# Altium schematic sheet Encoder.SchDoc(Encoder)
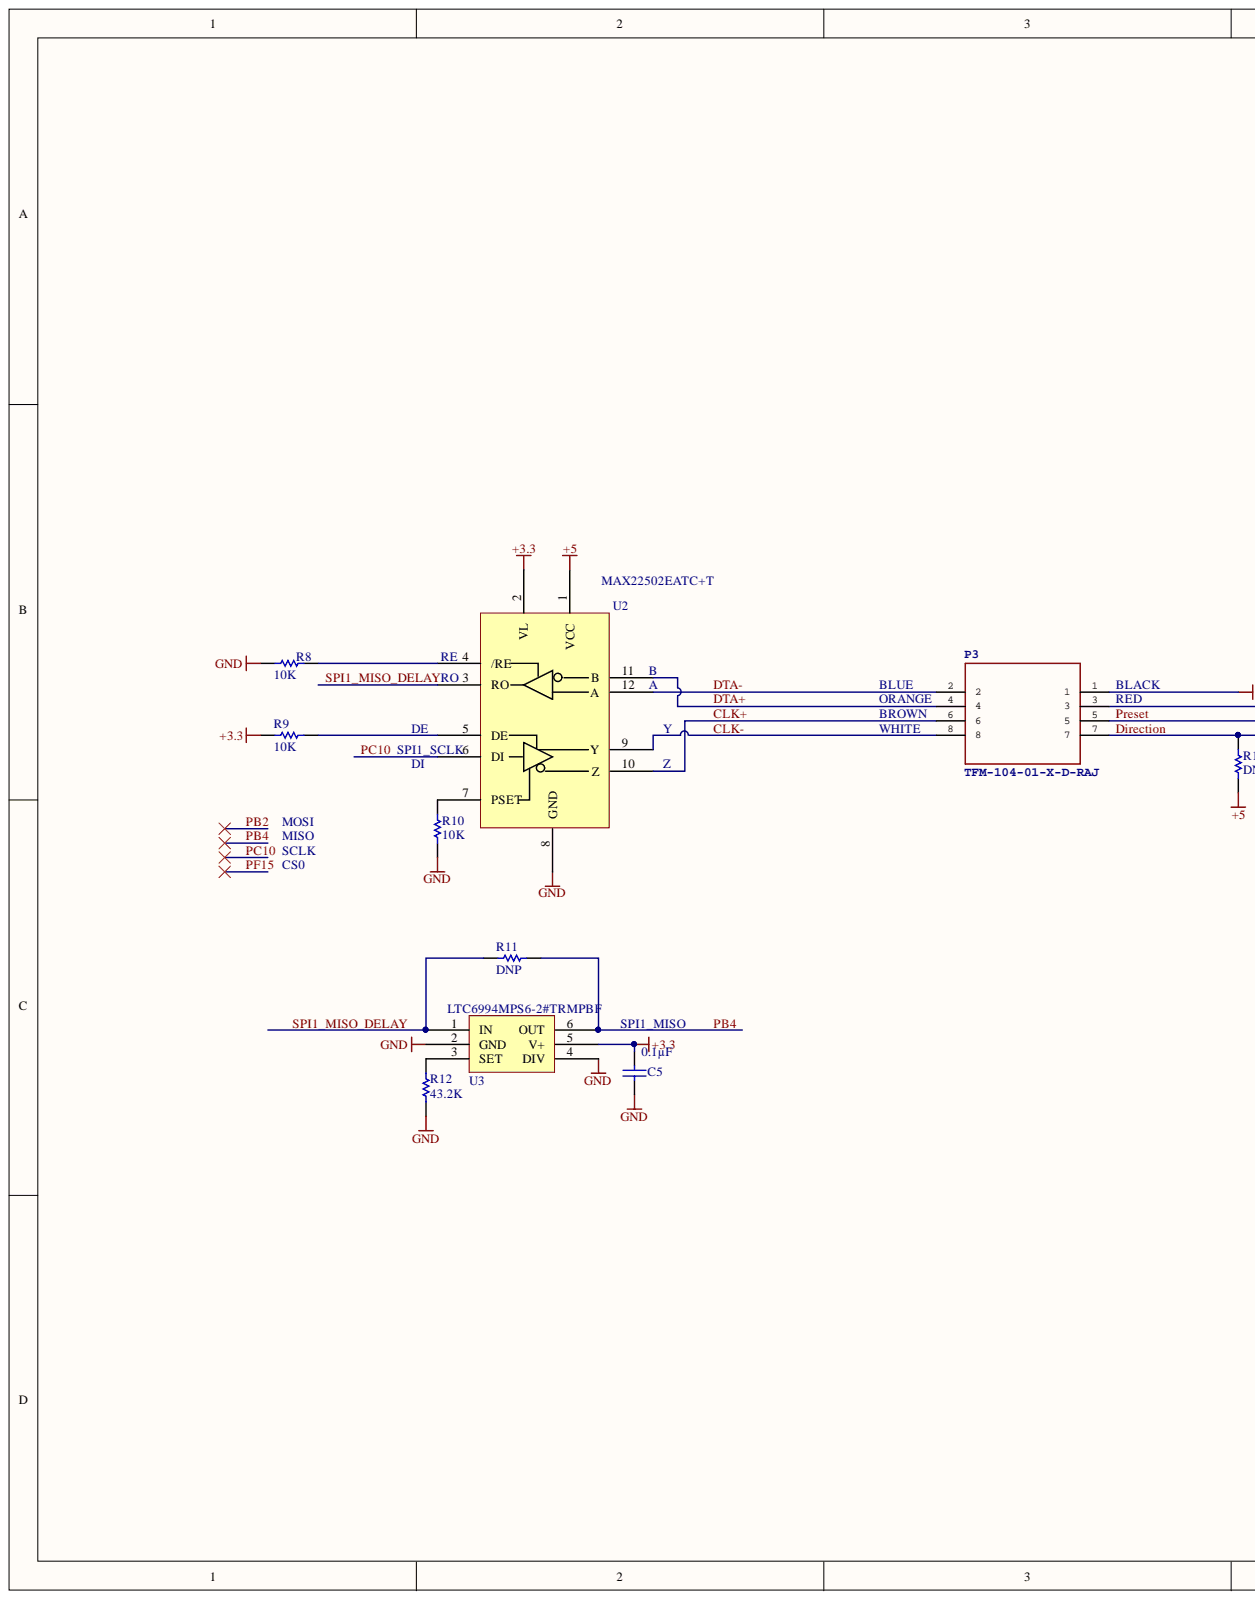
[diagram p0: Encoder.SchDoc, left]
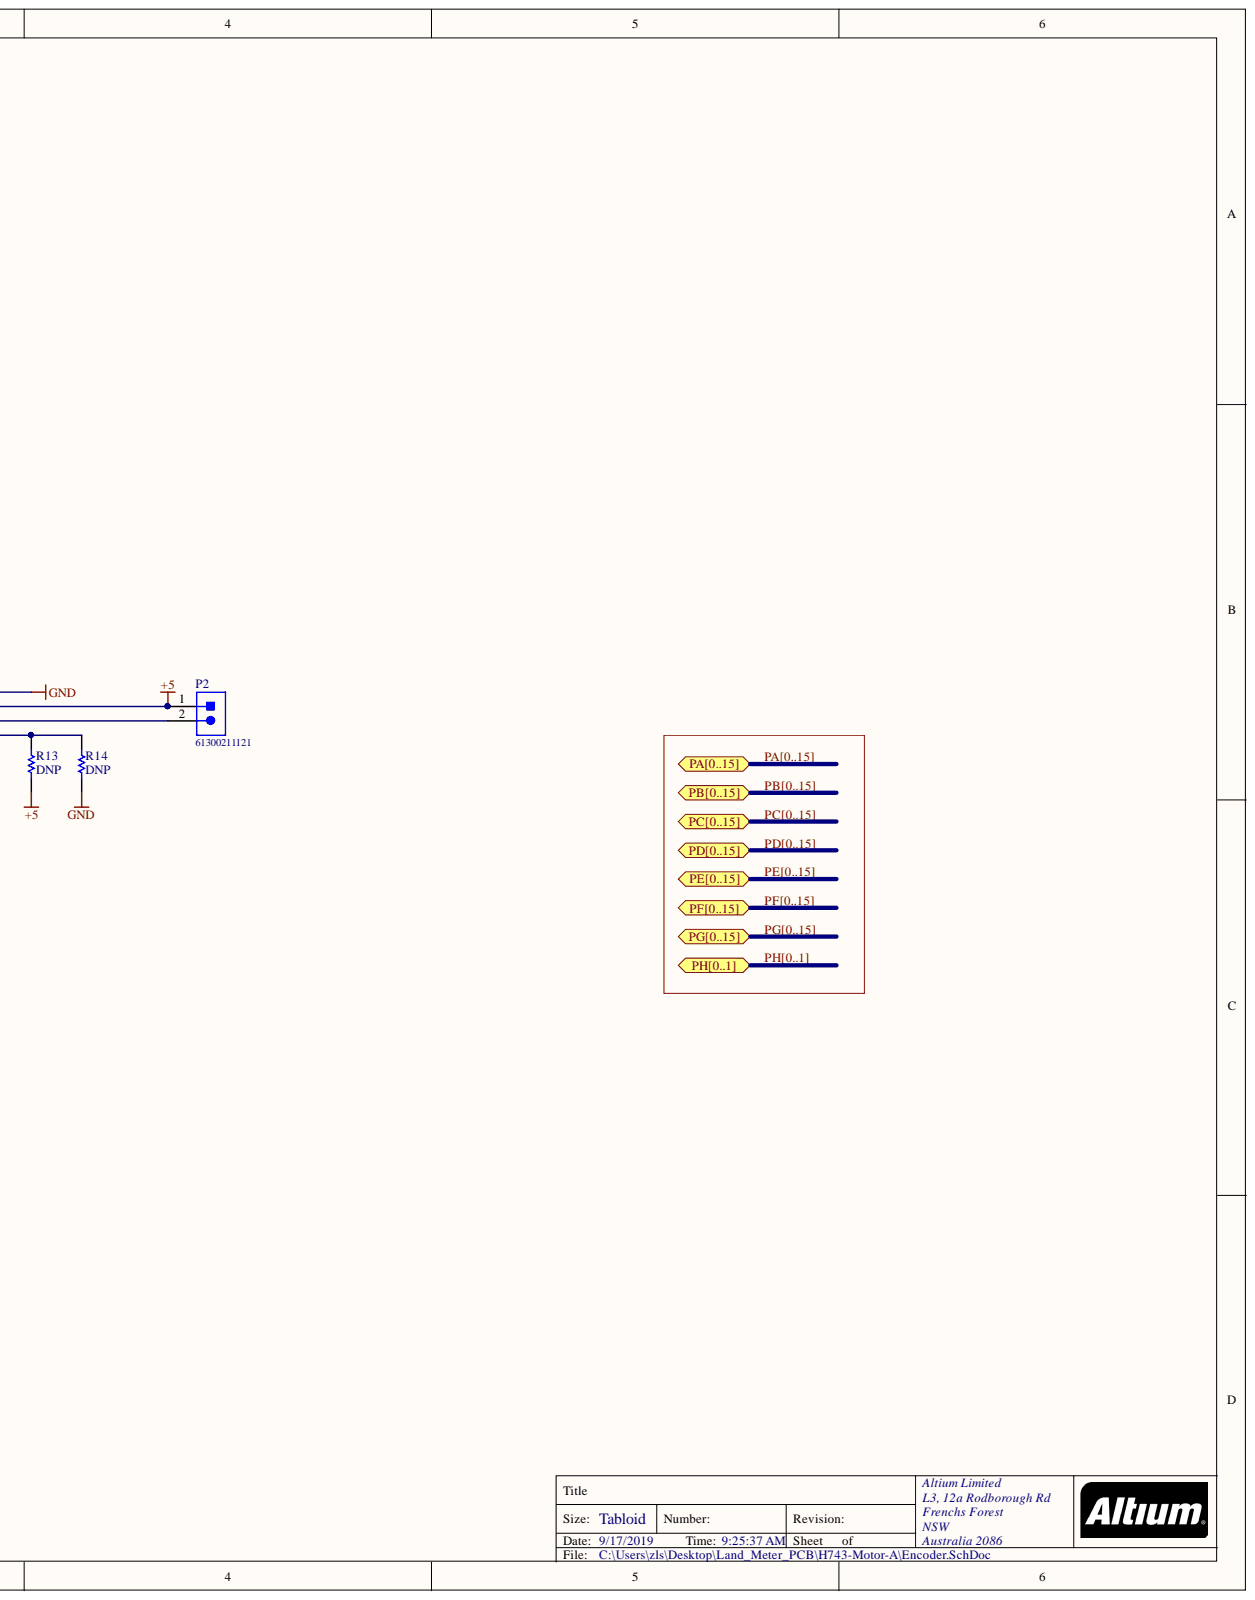
[diagram p1: Encoder.SchDoc, right]

## Parts
C5  Capacitance: 0.1µF  [CAPC0805(2012)145_N]  (hidden comment: CAP CER 0.1UF 25V X7R 0805)
P2  61300211121  [61300211121]
P3  TFM-104-01-X-D-RAJ  [SAMTEC-TFM-104-01-X-D-RA]
R8  10K  [RESC0805(2012)_L]
R9  10K  [RESC0805(2012)_L]
R10  10K  [RESC0805(2012)_L]
R11  DNP  [RESC0805(2012)_L]
R12  43.2K  [RESC0805(2012)_L]
R13  DNP  [RESC0805(2012)_L]
R14  DNP  [RESC0805(2012)_L]
U2  MAX22502EATC+T  [MAX22502EATC+T]
U3  LTC6994MPS6-2#TRMPBF  [LTC6994MPS6-2#TRMPBF]

## Ports
PA0, PA1, PA2, PA3, PA4, PA5, PA6, PA7, PA8, PA9, PA10, PA11, PA12, PA13, PA14, PA15, PA[0..15], PB0, PB1, PB2, PB3, PB4, PB5, PB6, PB7, PB8, PB9, PB10, PB11, PB12, PB13, PB14, PB15, PB[0..15], PC0, PC1, PC2, PC3, PC4, PC5, PC6, PC7, PC8, PC9, PC10, PC11, PC12, PC13, PC14, PC15, PC[0..15], PD0, PD1, PD2, PD3, PD4, PD5, PD6, PD7, PD8, PD9, PD10, PD11, PD12, PD13, PD14, PD15, PD[0..15], PE0, PE1, PE2, PE3, PE4, PE5, PE6, PE7, PE8, PE9, PE10, PE11, PE12, PE13, PE14, PE15, PE[0..15], PF0, PF1, PF2, PF3, PF4, PF5, PF6, PF7, PF8, PF9, PF10, PF11, PF12, PF13, PF14, PF15, PF[0..15], PG0, PG1, PG2, PG3, PG4, PG5, PG6, PG7, PG8, PG9, PG10, PG11, PG12, PG13, PG14, PG15, PG[0..15], PH0, PH1, PH[0..1]

## Nets
+3.3: C5.1 R9.2 U2.2(VL) U3.5(V+)
+5: P2.1 P3.3 R13.1 U2.1(VCC)
GND: C5.2 P3.1 R8.2 R10.2 R12.2 R14.1 U2.8(GND) U3.2(GND) U3.4(DIV)
CLK+: P3.6 U2.10(Z)
CLK-: P3.8 U2.9(Y)
Direction: P3.7 R13.2 R14.2
DTA+: P3.4 U2.11(B)
DTA-: P3.2 U2.12(A)
PB4: R11.2 U3.6(OUT) ; port PB[0..15]  [also labelled PB]
PC10: U2.6(DI) ; port PC[0..15]  [also labelled PC]
Preset: P2.2 P3.5
SPI1_MISO_DELAY: R11.1 U2.3(RO) U3.1(IN)
NetR8_1: R8.1 U2.4(/RE)
NetR9_1: R9.1 U2.5(DE)
NetR10_1: R10.1 U2.7(PSET)
NetR12_1: R12.1 U3.3(SET)
PA0: ; port PA[0..15]  [also labelled PA]
PA1: ; port PA[0..15]  [also labelled PA]
PA2: ; port PA[0..15]  [also labelled PA]
PA3: ; port PA[0..15]  [also labelled PA]
PA4: ; port PA[0..15]  [also labelled PA]
PA5: ; port PA[0..15]  [also labelled PA]
PA6: ; port PA[0..15]  [also labelled PA]
PA7: ; port PA[0..15]  [also labelled PA]
PA8: ; port PA[0..15]  [also labelled PA]
PA9: ; port PA[0..15]  [also labelled PA]
PA10: ; port PA[0..15]  [also labelled PA]
PA11: ; port PA[0..15]  [also labelled PA]
PA12: ; port PA[0..15]  [also labelled PA]
PA13: ; port PA[0..15]  [also labelled PA]
PA14: ; port PA[0..15]  [also labelled PA]
PA15: ; port PA[0..15]  [also labelled PA]
PB0: ; port PB[0..15]  [also labelled PB]
PB1: ; port PB[0..15]  [also labelled PB]
PB2: ; port PB[0..15]  [also labelled PB]
PB3: ; port PB[0..15]  [also labelled PB]
PB5: ; port PB[0..15]  [also labelled PB]
PB6: ; port PB[0..15]  [also labelled PB]
PB7: ; port PB[0..15]  [also labelled PB]
PB8: ; port PB[0..15]  [also labelled PB]
PB9: ; port PB[0..15]  [also labelled PB]
PB10: ; port PB[0..15]  [also labelled PB]
PB11: ; port PB[0..15]  [also labelled PB]
PB12: ; port PB[0..15]  [also labelled PB]
PB13: ; port PB[0..15]  [also labelled PB]
PB14: ; port PB[0..15]  [also labelled PB]
PB15: ; port PB[0..15]  [also labelled PB]
PC0: ; port PC[0..15]  [also labelled PC]
PC1: ; port PC[0..15]  [also labelled PC]
PC2: ; port PC[0..15]  [also labelled PC]
PC3: ; port PC[0..15]  [also labelled PC]
PC4: ; port PC[0..15]  [also labelled PC]
PC5: ; port PC[0..15]  [also labelled PC]
PC6: ; port PC[0..15]  [also labelled PC]
PC7: ; port PC[0..15]  [also labelled PC]
PC8: ; port PC[0..15]  [also labelled PC]
PC9: ; port PC[0..15]  [also labelled PC]
PC11: ; port PC[0..15]  [also labelled PC]
PC12: ; port PC[0..15]  [also labelled PC]
PC13: ; port PC[0..15]  [also labelled PC]
PC14: ; port PC[0..15]  [also labelled PC]
PC15: ; port PC[0..15]  [also labelled PC]
PD0: ; port PD[0..15]  [also labelled PD]
PD1: ; port PD[0..15]  [also labelled PD]
PD2: ; port PD[0..15]  [also labelled PD]
PD3: ; port PD[0..15]  [also labelled PD]
PD4: ; port PD[0..15]  [also labelled PD]
PD5: ; port PD[0..15]  [also labelled PD]
PD6: ; port PD[0..15]  [also labelled PD]
PD7: ; port PD[0..15]  [also labelled PD]
PD8: ; port PD[0..15]  [also labelled PD]
PD9: ; port PD[0..15]  [also labelled PD]
PD10: ; port PD[0..15]  [also labelled PD]
PD11: ; port PD[0..15]  [also labelled PD]
PD12: ; port PD[0..15]  [also labelled PD]
PD13: ; port PD[0..15]  [also labelled PD]
PD14: ; port PD[0..15]  [also labelled PD]
PD15: ; port PD[0..15]  [also labelled PD]
PE0: ; port PE[0..15]  [also labelled PE]
PE1: ; port PE[0..15]  [also labelled PE]
PE2: ; port PE[0..15]  [also labelled PE]
PE3: ; port PE[0..15]  [also labelled PE]
PE4: ; port PE[0..15]  [also labelled PE]
PE5: ; port PE[0..15]  [also labelled PE]
PE6: ; port PE[0..15]  [also labelled PE]
PE7: ; port PE[0..15]  [also labelled PE]
PE8: ; port PE[0..15]  [also labelled PE]
PE9: ; port PE[0..15]  [also labelled PE]
PE10: ; port PE[0..15]  [also labelled PE]
PE11: ; port PE[0..15]  [also labelled PE]
PE12: ; port PE[0..15]  [also labelled PE]
PE13: ; port PE[0..15]  [also labelled PE]
PE14: ; port PE[0..15]  [also labelled PE]
PE15: ; port PE[0..15]  [also labelled PE]
PF0: ; port PF[0..15]  [also labelled PF]
PF1: ; port PF[0..15]  [also labelled PF]
PF2: ; port PF[0..15]  [also labelled PF]
PF3: ; port PF[0..15]  [also labelled PF]
PF4: ; port PF[0..15]  [also labelled PF]
PF5: ; port PF[0..15]  [also labelled PF]
PF6: ; port PF[0..15]  [also labelled PF]
PF7: ; port PF[0..15]  [also labelled PF]
PF8: ; port PF[0..15]  [also labelled PF]
PF9: ; port PF[0..15]  [also labelled PF]
PF10: ; port PF[0..15]  [also labelled PF]
PF11: ; port PF[0..15]  [also labelled PF]
PF12: ; port PF[0..15]  [also labelled PF]
PF13: ; port PF[0..15]  [also labelled PF]
PF14: ; port PF[0..15]  [also labelled PF]
PF15: ; port PF[0..15]  [also labelled PF]
PG0: ; port PG[0..15]  [also labelled PG]
PG1: ; port PG[0..15]  [also labelled PG]
PG2: ; port PG[0..15]  [also labelled PG]
PG3: ; port PG[0..15]  [also labelled PG]
PG4: ; port PG[0..15]  [also labelled PG]
PG5: ; port PG[0..15]  [also labelled PG]
PG6: ; port PG[0..15]  [also labelled PG]
PG7: ; port PG[0..15]  [also labelled PG]
PG8: ; port PG[0..15]  [also labelled PG]
PG9: ; port PG[0..15]  [also labelled PG]
PG10: ; port PG[0..15]  [also labelled PG]
PG11: ; port PG[0..15]  [also labelled PG]
PG12: ; port PG[0..15]  [also labelled PG]
PG13: ; port PG[0..15]  [also labelled PG]
PG14: ; port PG[0..15]  [also labelled PG]
PG15: ; port PG[0..15]  [also labelled PG]
PH0: ; port PH[0..1]  [also labelled PH]
PH1: ; port PH[0..1]  [also labelled PH]

## Library data of the parts
C5 = CMP-1036-04795-1: Manufacturer Part Number: GRM21BR71E104KA01L; Manufacturer: Murata Electronics North America; Tolerance: ±10%; Voltage - Rated: 25V; Thickness (Max): 0.053" (1.35mm); Temperature Coefficient: X7R; Supplier Part Number 1: 490-1673-6-ND; Supplier 1: Digi-Key; Stock 1: 891652; Size / Dimension: 0.079" L x 0.049" W (2.00mm x 1.25mm); RoHS: RoHS Compliant; Ratings: -; Pricing 1: 1=0.2, 10=0.145, 100=0.0683, 500=0.04788, 1000=0.03964 (USD); Part Status: Active; Packaging: Digi-Reel®; Package / Case: 0805 (2012 Metric); Operating Temperature: -55°C ~ 125°C; Mounting Type: Surface Mount, MLCC; Library Name: Altium Content Vault; Lead Style: -; Lead Spacing: -; Height - Seated (Max): -; Features: -; Description: CAP CER 0.1UF 25V X7R 0805; ComponentLink1URL: http://www.murata.com/~/media/webrenewal/support/library/catalog/products/capacitor/mlcc/c02e.ashx; ComponentLink1Description: http://www.murata.com/~/media/webrenewal/support/library/catalog/products/capacitor/mlcc/c02e.ashx; Component Kind: Standard; Category: Capacitors; Applications: General Purpose
P2 = CMP-1502-01060-1: THT Vertical Pin Header WR-PHD, Pitch 2.54 mm, Single Row, 2 pins; Manufacturer: Wurth Elektronik; Working Voltage: 250VAC; Withstanding Voltage: 500VAC; Voltage Rating: 250V; Rated Current: 3A; Pitch: 2.54mm; Pin Number: 2; Gender: Male; Contact Resistance: 20mOhm; Connector Type: Male Pin; Termination: Through Hole; Supplier Part Number 1: 732-5315-ND; Supplier 1: Digi-Key; Stock 1: 179707; Row Number: Single; RoHS: Yes; REACH SVHC: Unknown; Pricing 1: 1=0.13000, 10=0.10600, 25=0.08800, 50=0.07400, 100=0.06700, 1000=0.06100 (USD); Part Number: 61300211121; Packaging: Bag; Package Reference: 61300211121; Orientation: Vertical; Operating Temperature: -40to105degC; Number of Rows: 1; Number of Positions: 2; Number of Contacts: 2; Mounting Technology: THT; Mount: Through Hole; Min Operating Temperature: -40°C; Max Operating Temperature: 125°C; Manufacturer URL: http://www.we-online.de; Manufacturer Part Number: 61300211121; Library Name: Altium Content Vault; Lead Free: Lead Free; Housing Material: PBT; Datasheet URL: https://katalog.we-online.de/em/datasheet/6130xx11121.pdf; Current Rating: 3A; Contact Plating: Gold; Contact Mating Length: 0.236inch; Contact Material: Copper Alloy,Copper; Contact Gender: Male; ComponentLink1URL: https://datasheet.ciiva.com/13619/6130xx11121-48870-13619345.pdf?src-supplier=Mouser; ComponentLink1Description: https://datasheet.ciiva.com/13619/6130xx11121-48870-13619345.pdf?src-supplier=Mouser; Component Kind: Standard; Color: Black; Publisher: WurthElektronik
P3 = SAMTEC-TFM-104-01-X-D-RAJ: Manufacturer: SAMTEC; Manufacturer Part Number: TFM-104-01-X-D-RAJ; Library Name: SAMTEC-TFM-104-01-X-D-RA.IntLib; Component Kind: Standard
R8-R10 = CMP-1013-00215-1: RES SMD 10K OHM 1% 1/8W 0805; Manufacturer Part Number: CRCW080510K0FKEA; Manufacturer: Vishay Dale; Temperature Coefficient: ±100ppm/°C; Power (Watts): 0.125W, 1/8W; Tolerance: ±1%; Supplier Part Number 1: 541-10.0KCCT-ND; Supplier Device Package: 0805; Supplier 1: Digi-Key; Stock 1: 1104982; Size / Dimension: 0.079" L x 0.049" W (2.00mm x 1.25mm); RoHS: RoHS Compliant; Pricing 1: 1=0.1, 10=0.055, 100=0.0223, 1000=0.01003, 2500=0.0087 (USD); Part Status: Active; Packaging: Cut Tape (CT); Package / Case: 0805 (2012 Metric); Operating Temperature: -55°C ~ 155°C; Library Name: Altium Content Vault; Height - Seated (Max): 0.020" (0.50mm); Features: Automotive AEC-Q200; Failure Rate: -; Composition: Thick Film; ComponentLink1URL: http://www.vishay.com/docs/20035/dcrcwe3.pdf; ComponentLink1Description: http://www.vishay.com/docs/20035/dcrcwe3.pdf; Component Kind: Standard; Category: Resistors
R11 = CMP-1013-00215-1: RES SMD 0 OHM 5% 1/8W 0805; Manufacturer Part Number: CRCW08050000Z0EA; Manufacturer: Vishay; Voltage Rating (DC): 150V; Tolerance: 1%; Temperature Coefficient: 100ppm/°C; Resistance: 0mR; Power Rating: 125mW; Width: 1.25mm; Weight: 0.000194oz; Voltage Rating: 150V; Termination: SMD/SMT; Supplier Part Number 1: 541-0.0ACT-ND; Supplier 1: Digi-Key; Stock 1: 470131; RoHS: Yes; REACH SVHC: Unknown; Radiation Hardening: No; Pricing 1: 1=0.10000, 10=0.04400, 25=0.03160, 50=0.02420, 100=0.01780, 250=0.01360, 500=0.01090, 1000=0.00802 (USD); Packaging: Tape and Reel; Package Quantity: 1; Number of Terminations: 2; Min Operating Temperature: -55°C; Military Standard: Not; Max Power Dissipation: 125mW; Max Operating Temperature: 155°C; Library Name: Altium Content Vault; Length: 2mm; Lead Free: Lead Free; Height: 0.45mm; Features: Automotive AEC-Q200; Depth: 1.25mm; Contact Plating: Tin,Lead; Composition: Thick Film; ComponentLink11URL: https://datasheet.ciiva.com/5543/dcrcwe3-109170-5543096.pdf?src-supplier=Mouser; ComponentLink11Description: https://datasheet.ciiva.com/5543/dcrcwe3-109170-5543096.pdf?src-supplier=Mouser; ComponentLink10URL: https://datasheet.ciiva.com/7841/1720486-7841839.pdf?src-supplier=Element14; ComponentLink10Description: https://datasheet.ciiva.com/7841/1720486-7841839.pdf?src-supplier=Element14; ComponentLink9URL: https://datasheet.ciiva.com/2463/dcrcwe3-2463039.pdf?src-supplier=Digi-Key; ComponentLink9Description: https://datasheet.ciiva.com/2463/dcrcwe3-2463039.pdf?src-supplier=Digi-Key; ComponentLink8URL: https://datasheet.ciiva.com/2463/dcrcwe3-2463038.pdf?src-supplier=Digi-Key; ComponentLink8Description: https://datasheet.ciiva.com/2463/dcrcwe3-2463038.pdf?src-supplier=Digi-Key; ComponentLink7URL: https://datasheet.ciiva.com/7841/1697337-7841629.pdf?src-supplier=Element14; ComponentLink7Description: https://datasheet.ciiva.com/7841/1697337-7841629.pdf?src-supplier=Element14; ComponentLink6URL: https://datasheet.ciiva.com/2463/dcrcwe3-2463037.pdf?src-supplier=Digi-Key; ComponentLink6Description: https://datasheet.ciiva.com/2463/dcrcwe3-2463037.pdf?src-supplier=Digi-Key; ComponentLink5URL: https://datasheet.ciiva.com/16719/70201119-16719706.pdf?src-supplier=Allied+Electronics; ComponentLink5Description: https://datasheet.ciiva.com/16719/70201119-16719706.pdf?src-supplier=Allied+Electronics; ComponentLink4URL: https://datasheet.ciiva.com/16389/dcrcwe3-16389338.pdf?src-supplier=Future+Electronics; ComponentLink4Description: https://datasheet.ciiva.com/16389/dcrcwe3-16389338.pdf?src-supplier=Future+Electronics; ComponentLink3URL: https://datasheet.ciiva.com/26314/fld-crcw-e3-data-en-26314630.pdf?src-supplier=Distrelec; ComponentLink3Description: https://datasheet.ciiva.com/26314/fld-crcw-e3-data-en-26314630.pdf?src-supplier=Distrelec; ComponentLink2URL: https://datasheet.ciiva.com/16883/dcrcwe3-16883622.pdf?src-supplier=Avnet+Europe; ComponentLink2Description: https://datasheet.ciiva.com/16883/dcrcwe3-16883622.pdf?src-supplier=Avnet+Europe; ComponentLink1URL: https://datasheet.ciiva.com/11811/0900766b80d98b26-11811304.pdf?src-supplier=RSComponents; ComponentLink1Description: https://datasheet.ciiva.com/11811/0900766b80d98b26-11811304.pdf?src-supplier=RSComponents; Component Kind: Standard; Case Code (Metric): 2012; Case Code (Imperial): 0805; Case/Package: 0805
R12 = CMP-1013-00215-1: RES SMD 43.2K OHM 1% 1/8W 0805; Manufacturer Part Number: CRCW080543K2FKEA; Manufacturer: Vishay Dale; Temperature Coefficient: ±100ppm/°C; Power (Watts): 0.125W, 1/8W; Tolerance: ±1%; Supplier Part Number 1: 541-43.2KCCT-ND; Supplier Device Package: 0805; Supplier 1: Digi-Key; Stock 1: 68577; Size / Dimension: 0.079" L x 0.049" W (2.00mm x 1.25mm); RoHS: RoHS Compliant; Pricing 1: 1=0.1, 10=0.055, 100=0.0223, 1000=0.01003, 2500=0.0087 (USD); Part Status: Active; Packaging: Cut Tape (CT); Package / Case: 0805 (2012 Metric); Operating Temperature: -55°C ~ 155°C; Library Name: Altium Content Vault; Height - Seated (Max): 0.020" (0.50mm); Features: Automotive AEC-Q200; Failure Rate: -; Composition: Thick Film; ComponentLink1URL: http://www.vishay.com/docs/20035/dcrcwe3.pdf; ComponentLink1Description: http://www.vishay.com/docs/20035/dcrcwe3.pdf; Component Kind: Standard; Category: Resistors
R13 R14 = CMP-1013-00215-1: RES SMD 0 OHM 5% 1/8W 0805; Library Name: Altium Content Vault; Component Kind: Standard
U2 = MAX22502EATC+T: PLANAR E CORES; Manufacturer: Maxim; Supplier Part Number 2: 700-MAX22502EATC+T; Supplier Part Number 1: MAX22502EATC+TCT-ND; Supplier 2: Mouser; Supplier 1: Digi-Key; Stock 2: 2498; Stock 1: 3993; RoHS: No; Pricing 2: 1=8.93000, 10=8.04000, 25=7.32000, 50=7.00000, 100=6.61000, 250=5.95000, 500=5.42000, 1000=4.57000, 2500=4.17000, 10000=4.17000 (USD); Pricing 1: 1=8.94000, 10=8.04200, 25=7.32680, 100=6.61210, 250=6.07596, 500=5.53986, 1000=4.82504 (USD); Manufacturer Part Number: MAX22502EATC+T; Component Kind: Standard; Library Name: ZLS_Schematic_lib.SchLib
U3 = LTC6994MPS6-2#TRMPBF: IC DELAY BLOCK 8TAP PROG TSOT23; Manufacturer Part Number: LTC6994CS6-2#TRMPBF; Manufacturer: Linear Technology/Analog Devices; Voltage - Supply: 2.25 V ~ 5.5 V; Function: Programmable; Available Total Delays: 1µs ~ 33.6s; Supplier Part Number 1: LTC6994CS6-2#TRMPBFCT-ND; Supplier Device Package: TSOT-23-6; Supplier 1: Digi-Key; Stock 1: 1401; RoHS: RoHS Compliant; Pricing 1: 1=3.42, 25=3.0496, 100=1.9217, 250=1.76156 (USD); Part Status: Active; Packaging: Cut Tape (CT); Package / Case: SOT-23-6 Thin, TSOT-23-6; Operating Temperature: 0°C ~ 70°C; Mounting Type: Surface Mount; Delay to 1st Tap: -; ComponentLink1URL: http://www.linear.com/docs/29725; ComponentLink1Description: http://www.linear.com/docs/29725; Component Kind: Standard; Category: Integrated Circuits (ICs); Base Part Number: LTC6994; Library Name: ZLS_Schematic_lib.SchLib
